# Altium schematic sheet BuckConverter24V.SchDoc(BuckConverter24V)
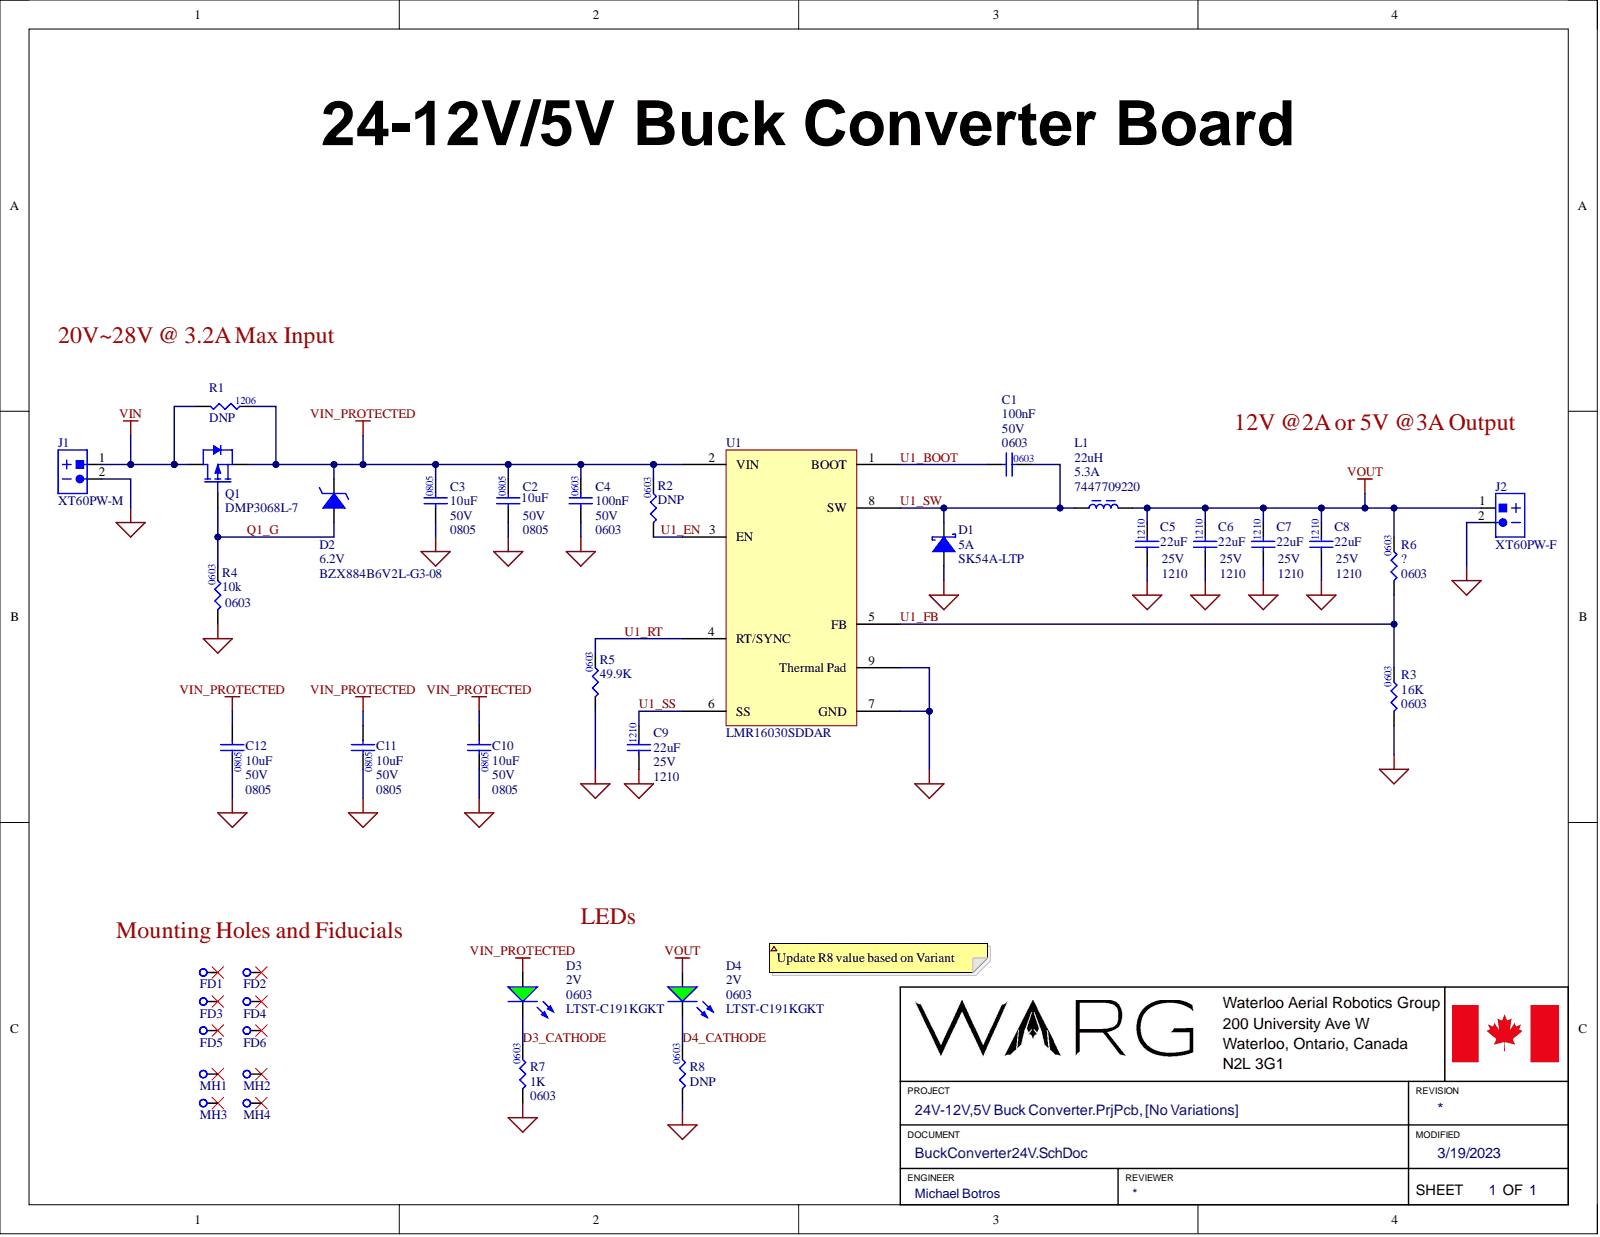
[diagram p0: BuckConverter24V.SchDoc]

## Parts
C1  Case/Package: 0603  Voltage: 50V  (hidden comment: CL10B104KB8NNNC)
C2  value 10uF  Case/Package: 0805  Voltage Rating: 50V  (hidden comment: GRM21BR61H106KE43L)
C3  value 10uF  Case/Package: 0805  Voltage Rating: 50V  (hidden comment: GRM21BR61H106KE43L)
C4  Capacitance: 100nF  Case/Package: 0603  Voltage: 50V  (hidden comment: CL10B104KB8NNNC)
C5  value 22uF  Case/Package: 1210  Voltage Rating (DC): 25V  (hidden comment: GRM32ER71E226KE15K)
C6  value 22uF  Case/Package: 1210  Voltage Rating (DC): 25V  (hidden comment: GRM32ER71E226KE15K)
C7  value 22uF  Case/Package: 1210  Voltage Rating (DC): 25V  (hidden comment: GRM32ER71E226KE15K)
C8  value 22uF  Case/Package: 1210  Voltage Rating (DC): 25V  (hidden comment: GRM32ER71E226KE15K)
C9  value 22uF  Case/Package: 1210  Voltage Rating (DC): 25V  (hidden comment: GRM32ER71E226KE15K)
C10  value 10uF  Case/Package: 0805  Voltage Rating: 50V  (hidden comment: GRM21BR61H106KE43L)
C11  value 10uF  Case/Package: 0805  Voltage Rating: 50V  (hidden comment: GRM21BR61H106KE43L)
C12  value 10uF  Case/Package: 0805  Voltage Rating: 50V  (hidden comment: GRM21BR61H106KE43L)
D1  SK54A-LTP  Average Rectified Current: 5A
D2  BZX884B6V2L-G3-08
D3  LTST-C191KGKT  Case/Package: 0603  Forward Voltage: 2V
D4  LTST-C191KGKT  Case/Package: 0603  Forward Voltage: 2V
FD1  FIDUCIAL-STANDARD  (value not drawn)
FD2  FIDUCIAL-STANDARD  (value not drawn)
FD3  FIDUCIAL-STANDARD  (value not drawn)
FD4  FIDUCIAL-STANDARD  (value not drawn)
FD5  FIDUCIAL-STANDARD  (value not drawn)
FD6  FIDUCIAL-STANDARD  (value not drawn)
J1  XT60PW-M
J2  XT60PW-F
L1  7447709220  Current Rating: 5.3A
MH1  M2HOLE-STANDARD  (value not drawn)
MH2  M2HOLE-STANDARD  (value not drawn)
MH3  M2HOLE-STANDARD  (value not drawn)
MH4  M2HOLE-STANDARD  (value not drawn)
Q1  DMP3068L-7
R1  value DNP  (hidden comment: .RES_1206_DNP)
R2  value DNP  (hidden comment: .RES_0603_DNP)
R3  Case/Package: 0603  Resistance: 16K  (hidden comment: RC0603FR-0716KL)
R4  Case/Package: 0603  Resistance: 10k  (hidden comment: RC0603DR-0710KL)
R5  value 49.9K  Case/Package: 0603  (hidden comment: RC0603FR-0749K9L)
R6  Package: 0603  (hidden comment: .RES_0603)
R6
R6
R7  value 1K  Case/Package: 0603  (hidden comment: RC0603FR-071KL)
R8  value DNP  (hidden comment: .RES_0603_DNP)
R8
R8
U1  LMR16030SDDAR

## Nets
GND: C2.1 C3.1 C4.1 C5.1 C6.1 C7.1 C8.1 C9.1 C10.2 C11.2 C12.2 D1.1 J1.2 J2.2 R3.1 R4.1 R5.1 R7.1 R8.1 U1.7(GND) U1.9(Thermal_Pad)
VIN: J1.1 Q1.3 R1.1
VIN_PROTECTED: C2.2 C3.2 C4.2 C10.1 C11.1 C12.1 D2.2 D3.1 Q1.2 R1.2 R2.2 U1.2(VIN)
VOUT: C5.2 C6.2 C7.2 C8.2 D4.1 J2.1 L1.2 R6.2
D3_CATHODE: D3.2 R7.2
D4_CATHODE: D4.2 R8.2
Q1_G: D2.1 Q1.1 R4.2
U1_BOOT: C1.1 U1.1(BOOT)
U1_EN: R2.1 U1.3(EN)
U1_FB: R3.2 R6.1 U1.5(FB)
U1_RT: R5.2 U1.4(RT/SYNC)
U1_SS: C9.2 U1.6(SS)
U1_SW: C1.2 D1.2 L1.1 U1.8(SW)

Not connected: FD1 1; FD2 1; FD3 1; FD4 1; FD5 1; FD6 1; MH1 1; MH2 1; MH3 1; MH4 1

## Library data of the parts
C1 = CMP-00018-4: CAP CER 0.1uF 50V X7R 0603; Capacitance: 100nF; Tolerance: 10%; Component Kind: Standard; Depth: 0.8mm; Dielectric: X7R; Height: 0.8mm; Lead Free: Lead Free; Length: 1.6mm; Max Operating Temperature: 125°C; Min Operating Temperature: -55°C; Mount: Surface Mount; Voltage Rating (DC): 50V; Library Name: WARG
C2 C3 C10-C12 = CMP-001-00027-1: CAP CER 10uF 50V X5R 0805; Component Kind: Standard; Dielectric: X5R; Library Name: WARG
C4 = CMP-00018-4: CAP CER 0.1uF 50V X7R 0603; Tolerance: 10%; Component Kind: Standard; Depth: 0.8mm; Dielectric: X7R; Height: 0.8mm; Lead Free: Lead Free; Length: 1.6mm; Max Operating Temperature: 125°C; Min Operating Temperature: -55°C; Mount: Surface Mount; Voltage Rating (DC): 50V; Library Name: WARG
C5-C9 = CMP-00073-1: CAP CER 22uF 25V X7R 1210; Tolerance: 10%; Component Kind: Standard; Dielectric: X7R; Library Name: WARG
D1 = CMP-00102-3: Diode Schottky 40V 5A 2-Pin SMA T/R; Case/Package: SMA; Component Kind: Standard; Max Reverse Voltage (DC): 40V; Library Name: WARG
D2 = CMP-00083-7: ZENER DIODE DFN1006-2A 6.2V 200mW; Current: 2A; Forward Voltage: 900mV; Power: 300mW; Component Kind: Standard; Zener Voltage: 6.2V; Library Name: WARG
D3 D4 = CMP-001-00018-5: LED GREEN 2V 0603; Forward Current: 20mA; Color: Green; Component Kind: Standard; Library Name: WARG
FD1-FD6 = CMP-00028-00000-1: Standard Fiducial; Component Kind: Standard; Library Name: WARG
J1 = CMP-00007-11: Board Mount XT60PW-M 2 Pin Male Connector; Manufacturer: TME; Component Kind: Standard; Library Name: WARG
J2 = CMP-00086-5: Board Mount XT60PW-F 2 Pin Female Connector; Manufacturer: TME; Component Kind: Standard; Library Name: WARG
L1 = CMP-00091-4: FIXED IND 22uH 5.3A 28mOhm SMD; Core Material: Ferrite; DC Resistance (DCR): 28mR; Tolerance: 20%; Case Code (Imperial): 1210; Component Kind: Standard; Inductance: 22uH; Mount: Surface Mount; Library Name: WARG
MH1-MH4 = CMP-00092-4: RFC in progress (Dimensions currently unapproved); Annular Ring Diameter: 4.2mm; Through Hole Diameter: 2.4mm; Component Kind: Standard; Library Name: WARG
Q1 = CMP-00084-2: DMP3068L Series 30 V 3.3 A P-Channel Enhancement Mode Mosfet - SOT-23-3; Continuous Drain Current (ID): 3.3A; Drain to Source Voltage (Vdss): 30V; Max Power Dissipation: 700mW; Rds On Max: 72mR; Vgs Max: 12V; Component Kind: Standard; Packaging: Cut Tape; Library Name: WARG
R1 = CMP-009-00021-1: DNP 1206 Resistor; Component Kind: Standard; Library Name: WARG
R2 R8 = CMP-009-00018-3: DNP 0603 Resistor; Component Kind: Standard; Library Name: WARG
R3 = CMP-00093-2: RES 16K OHM 1% 1/10W 0603; Max Power Dissipation: 100mW; Voltage Rating: 50V; Component Kind: Standard; Tolerance: 1%; Library Name: WARG
R4 = CMP-001-00026-1: RES 10K OHM 0.5% 1/10W 0603; Power Rating: 100mW; Component Kind: Standard; Tolerance: 0.5%; Library Name: WARG
R5 = CMP-00070-1: RES 49.9K OHM 1% 1/10W 0603; Power Rating: 100mW; Voltage Rating: 50V; Component Kind: Standard; Tolerance: 1%; Library Name: WARG
R6 = CMP-009-00000-4: GENERIC 0603 RES; Component Kind: Standard; Resistance: ?; Library Name: WARG
R7 = CMP-009-00012-3: RES 1k OHM 1% 1/10W 0603; Component Kind: Standard; Library Name: WARG
U1 = CMP-00077-12: Buck Switching Regulator IC Positive Adjustable 0.8V 1 Output 3A 8-SOIC (0.154, 3.90mm Width) Exposed Pad; Max Input Voltage: 60V; Max Output Voltage: 50V; Min Input Voltage: 4.3V; Min Output Voltage: 800mV; Quiescent Current: 40uA; Component Kind: Standard; Mount: Surface Mount; Number of Pins: 8; Output Current: 3A; Output Type: Adjustable; Library Name: WARG
